# Altium schematic sheet BL_TH_BD.SchDoc
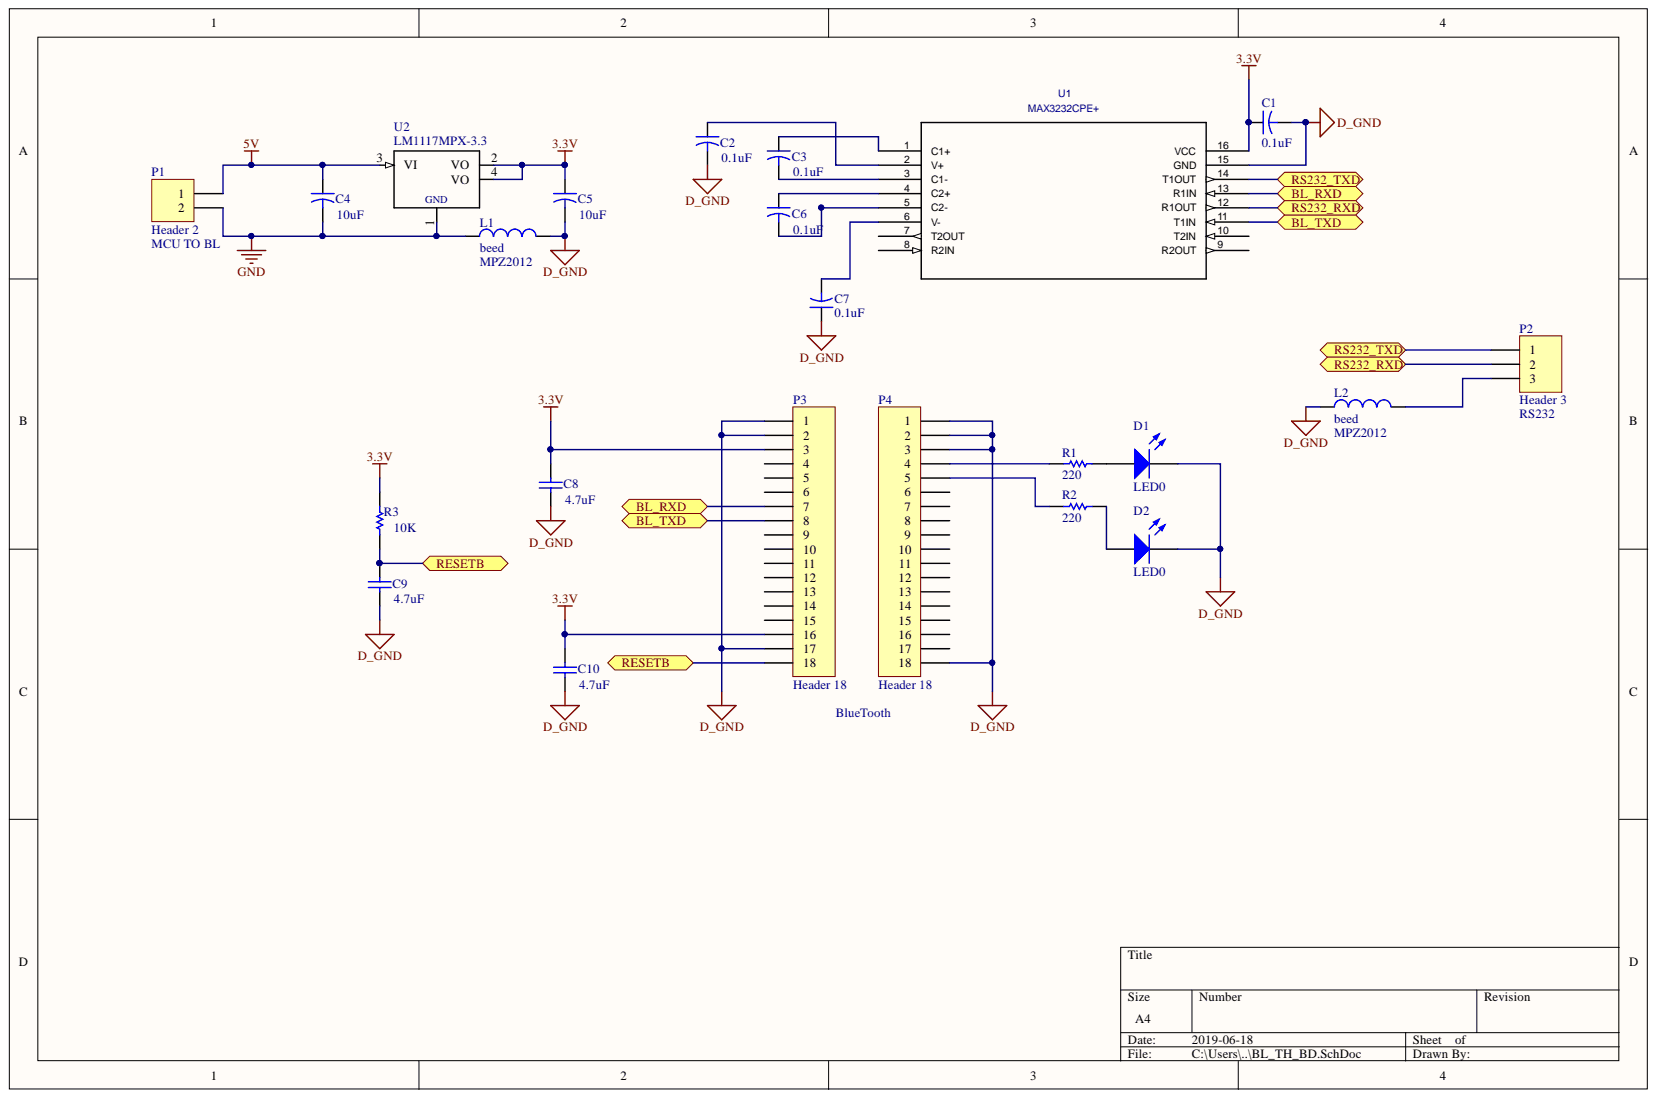
[diagram p0: BL_TH_BD.SchDoc]

## Parts
C1  value 0.1uF  [CAPR5-4X5]  (hidden comment: Cap2)
C2  value 0.1uF  [CAPR5-4X5]  (hidden comment: Cap2)
C3  value 0.1uF  [CAPR5-4X5]  (hidden comment: Cap2)
C4  value 10uF  [CAPR5-4X5]  (hidden comment: Cap2)
C5  value 10uF  [CAPR5-4X5]  (hidden comment: Cap2)
C6  value 0.1uF  [CAPR5-4X5]  (hidden comment: Cap2)
C7  value 0.1uF  [CAPR5-4X5]  (hidden comment: Cap2)
C8  value 4.7uF  [RAD-0.3]  (hidden comment: Cap)
C9  value 4.7uF  [RAD-0.3]  (hidden comment: Cap)
C10  value 4.7uF  [RAD-0.3]  (hidden comment: Cap)
D1  LED0  [LED-0]
D2  LED0  [LED-0]
L1  beed  value MPZ2012  [0402-A]
L2  beed  value MPZ2012  [0402-A]
P1  Header 2  [HDR1X2]
P2  Header 3  [HDR1X3]
P3  Header 18  [HDR1X18]
P4  Header 18  [HDR1X18]
R1  value 220  [AXIAL-0.3]  (hidden comment: Res1)
R2  value 220  [AXIAL-0.3]  (hidden comment: Res1)
R3  value 10K  [AXIAL-0.3]  (hidden comment: Res1)
U1  MAX3232CPE+  [21-0043D_16]
U2  LM1117MPX-3.3  [*SOT230P700X180-4N]

## Ports
BL_RXD, BL_TXD, RESETB, RS232_RXD, RS232_TXD

## Nets
3.3V: C1.1 C5.1 C8.2 C10.2 P3.3 P3.16 R3.2 U1.16(VCC) U2.2(VO) U2.4(VO)
5V: C4.1 P1.1 U2.3(VI)
D_GND: C1.2 C2.2 C5.2 C7.1 C8.1 C9.1 C10.1 D1.2 D2.2 L1.2 L2.1 P3.1 P3.2 P3.17 P4.1 P4.2 P4.3 P4.18 U1.15(GND)
GND: C4.2 L1.1 P1.2 U2.1
BL_RXD: P3.7 U1.13(R1IN) ; port BL_RXD
BL_TXD: P3.8 U1.11(T1IN) ; port BL_TXD
RESETB: C9.2 P3.18 R3.1 ; port RESETB
RS232_RXD: P2.2 U1.12(R1OUT) ; port RS232_RXD
RS232_TXD: P2.1 U1.14(T1OUT) ; port RS232_TXD
NetC2_1: C2.1 U1.2(V+)
NetC3_1: C3.1 U1.1(C1+)
NetC3_2: C3.2 U1.3(C1-)
NetC6_1: C6.1 U1.4(C2+)
NetC6_2: C6.2 U1.5(C2-)
NetC7_2: C7.2 U1.6(V-)
NetD1_1: D1.1 R1.1
NetD2_1: D2.1 R2.1
NetL2_2: L2.2 P2.3
NetP4_4: P4.4 R1.2
NetP4_5: P4.5 R2.2

Not connected: P3 4 5 6 9 10 11 12 13 14 15; P4 6 7 8 9 10 11 12 13 14 15 16 17; U1 7(T2OUT) 8(R2IN) 9(R2OUT) 10(T2IN)

## Library data of the parts
C1-C7 = Cap2: Capacitor; Component Kind: Standard; Library Name: Miscellaneous Devices.IntLib; PackageDescription: Capacitor; 2 Leads; PackageReference: CAPR5-4X5; Published: 23-Sep-2002; Signal Integrity: Cap; Simulation: CAP; Publisher: Altium Limited
C8-C10 = Cap: Capacitor; Component Kind: Standard; LatestRevisionDate: 17-Jul-2002; LatestRevisionNote: Re-released for DXP Platform.; Library Name: Miscellaneous Devices.IntLib; PackageDescription: Radial Cap, Thru-Hole; 2 Leads; 0.3 in Pin Spacing; PackageReference: RAD-0.3; Published: 8-Jun-2000; Signal Integrity: Cap; Simulation: CAP; Publisher: Altium Limited
D1 D2 = LED0: Typical INFRARED GaAs LED; Component Kind: Standard; LatestRevisionDate: 08-Jul-2005; LatestRevisionNote: Mech Layer 1 removed.; Library Name: Miscellaneous Devices.IntLib; PackageDescription: LED; 2 Leads; PackageReference: LED-0; Published: 8-Jun-2000; Simulation: LED0; Publisher: Altium Limited
L1 L2 = Inductor: Code_IEC: 1005; Code_JEITA: 0402; Component Kind: Standard; Description: Inductor; LatestRevisionDate: 29-May-2009; LatestRevisionNote: IPC-7351 Footprint Added.; Library Name: Miscellaneous Devices.IntLib; PackageDescription: Chip Inductor; PackageReference: 0402-A; Published: 8-Jun-2000; Signal Integrity: Inductor; Simulation: INDUCTOR; Publisher: Altium Limited
P1 = Header 2: Header, 2-Pin; Component Kind: Standard; LatestRevisionDate: 17-Jul-2002; LatestRevisionNote: Re-released for DXP Platform.; Library Name: Miscellaneous Connectors.IntLib; Signal Integrity: Connector; Publisher: Altium Limited
P2 = Header 3: Header, 3-Pin; Component Kind: Standard; LatestRevisionDate: 17-Jul-2002; LatestRevisionNote: Re-released for DXP Platform.; Library Name: Miscellaneous Connectors.IntLib; Signal Integrity: Connector; Publisher: Altium Limited
P3 P4 = Header 18: Header, 18-Pin; Component Kind: Standard; LatestRevisionDate: 17-Jul-2002; LatestRevisionNote: Re-released for DXP Platform.; Library Name: Miscellaneous Connectors.IntLib; Signal Integrity: Connector; Publisher: Altium Limited
R1-R3 = Res1: Resistor; Component Kind: Standard; LatestRevisionDate: 17-Jul-2002; LatestRevisionNote: Re-released for DXP Platform.; Library Name: Miscellaneous Devices.IntLib; PackageDescription: Axial Device, Thru-Hole; 2 Leads; 0.3 in Pin Spacing; PackageReference: AXIAL-0.3; Published: 8-Jun-2000; Signal Integrity: Res; Simulation: RESISTOR; Publisher: Altium Limited
U1 = MAX3232CPE+: Built_By: EMA_UL_Team; C: Copyright (C) 2015 Accelerated Designs. All rights reserved; Vendor: Maxim Integrated Products; Component Kind: Standard; Library Name: MAX3232CPE.SchLib; Manufacturer_Part_Number: MAX3232CPE+; Value: MAX3232CPE+
U2 = LM1117MPX-3.3: 4 pin 800mA Low-Dropout Linear Regulator 2000 per reel; MF: Texas Instruments; Availability: Unavailable; Component Kind: Standard; Library Name: LM1117MPX-3.3.IntLib; MP: LM1117MPX-3.3; Package: SOT-223 Texas Instruments; Price: None; SnapEDA_Link: https://www.snapeda.com/parts/LM1117MPX-3.3/Texas%20Instruments/view-part/?ref=snap
